# Altium schematic sheet LTE_adapter_Rev02.SchDoc
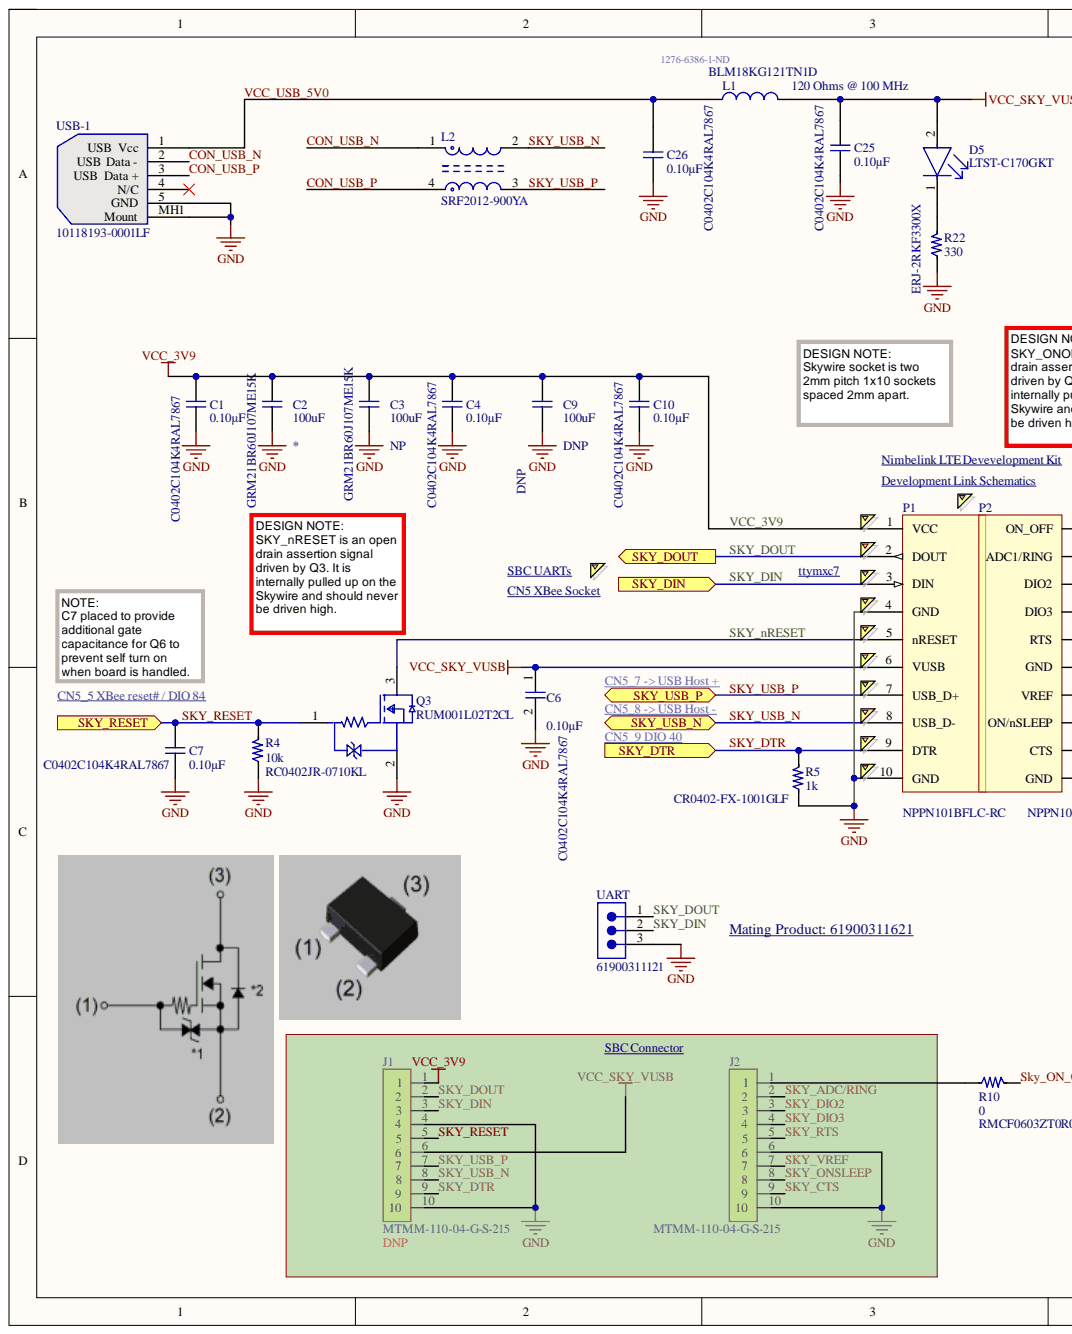
[diagram p0: LTE_adapter_Rev02.SchDoc, left]
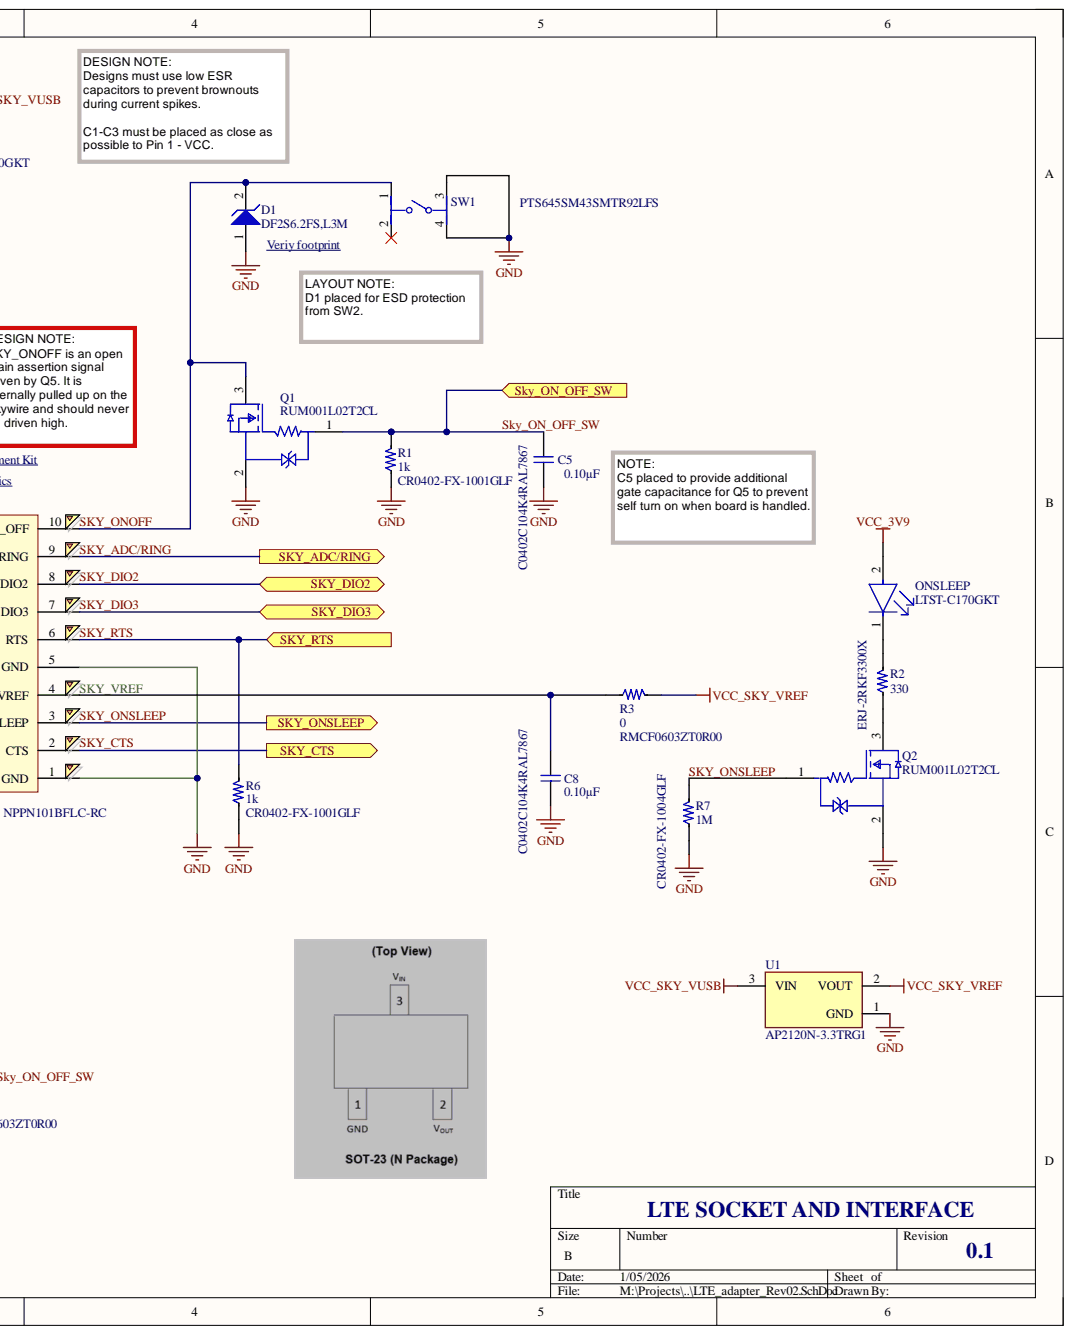
[diagram p1: LTE_adapter_Rev02.SchDoc, right]

## Parts
C1  C0402C104K4RAL7867  Capacitance: 0.10µF  [SMD CAP 1005]
C2  GRM21BR60J107ME15K  Capacitance: 100uF  [CAPC1210M]
C3  GRM21BR60J107ME15K  Capacitance: 100uF  Population: NP  [CAPC1210M]
C4  C0402C104K4RAL7867  Capacitance: 0.10µF  [SMD CAP 1005]
C5  C0402C104K4RAL7867  Capacitance: 0.10µF  [SMD CAP 1005]
C6  C0402C104K4RAL7867  Capacitance: 0.10µF  [SMD CAP 1005]
C7  C0402C104K4RAL7867  Capacitance: 0.10µF  [SMD CAP 1005]
C8  C0402C104K4RAL7867  Capacitance: 0.10µF  [SMD CAP 1005]
C9  DNP  Capacitance: 100uF  [CAPC1210M]
C10  C0402C104K4RAL7867  Capacitance: 0.10µF  [SMD CAP 1005]
C25  C0402C104K4RAL7867  Capacitance: 0.10µF  [SMD CAP 1005]
C26  C0402C104K4RAL7867  Capacitance: 0.10µF  [SMD CAP 1005]
D1  DF2S6.2FS,L3M  [SODFL1006X40N]
D5  LTST-C170GKT  [FP-LTST-C170GKT-MFG]
J1  MTMM-110-04-G-S-215  Assy Note: DNP  [HDRV10W50P200_10X1_2000X200H696]
J2  MTMM-110-04-G-S-215  [HDRV10W50P200_10X1_2000X200H696]
L1  BLM18KG121TN1D  value 120 Ohms @ 100 MHz  Supplier Part Number 1: 1276-6386-1-ND  [INDC1608M]
L2  SRF2012-900YA  [FP-SRF2012A-MFG]
ONSLEEP  LTST-C170GKT  [FP-LTST-C170GKT-MFG]
P1  NPPN101BFLC-RC  [NPPN101BFLC-RC]
P2  NPPN101BFLC-RC  [NPPN101BFLC-RC]
Q1  RUM001L02T2CL  [FP-VMT3-MFG]
Q2  RUM001L02T2CL  [FP-VMT3-MFG]
Q3  RUM001L02T2CL  [FP-VMT3-MFG]
R1  CR0402-FX-1001GLF  Resistance_Ohms: 1k  [SMD RES 1005]
R2  ERJ-2RKF3300X  [SMD RES 1005]
R3  RMCF0603ZT0R00  [RESC1608M]
R4  RC0402JR-0710KL  Resistance_Ohms: 10k  [SMD RES 1005]
R5  CR0402-FX-1001GLF  Resistance_Ohms: 1k  [SMD RES 1005]
R6  CR0402-FX-1001GLF  Resistance_Ohms: 1k  [SMD RES 1005]
R7  CR0402-FX-1004GLF  Resistance: 1M  [SMD RES 1005]
R10  RMCF0603ZT0R00  [RESC1608M]
R22  ERJ-2RKF3300X  [SMD RES 1005]
SW1  PTS645SM43SMTR92LFS  [FP-PTS645SM43SMTR92LFS-MFG]
U1  AP2120N-3.3TRG1  [FP-SOT23-MFG]
UART  61900311121  [61900311121]
USB-1  10118193-0001LF  [10118193-0001LF Rev01]

## Ports
SKY_ADC/RING, SKY_CTS, SKY_DIN, SKY_DIO2, SKY_DIO3, SKY_DOUT, SKY_DTR, Sky_ON_OFF_SW, SKY_ONSLEEP, SKY_RESET, SKY_RTS, SKY_USB_N, SKY_USB_P

## Nets
GND: C1.2 C2.2 C3.2 C4.2 C5.2 C6.2 C7.2 C8.2 C9.2 C10.2 C25.2 C26.2 D1.1 J1.4 J1.10 J2.6 J2.10 P1.4(GND) P1.10(GND) P2.1(GND) P2.5(GND) Q1.2 Q2.2 Q3.2 R1.1 R4.1 R5.1 R6.1 R7.1 R22.1 SW1.3 SW1.4 U1.1 UART.3 USB-1.5(GND) USB-1.MH1(Mount)
VCC_SKY_VREF: R3.2 U1.2
VCC_SKY_VUSB: C6.1 C25.1 D5.2 J1.6 L1.1 P1.6(VUSB) U1.3
CON_USB_N: L2.1 USB-1.2(Data_-)
CON_USB_P: L2.4 USB-1.3(Data_+)
SKY_ADC/RING: J2.2 P2.9(ADC1/RING) ; port SKY_ADC/RING
SKY_CTS: J2.9 P2.2(CTS) ; port SKY_CTS
SKY_DIN: J1.3 P1.3(DIN) UART.2 ; port SKY_DIN
SKY_DIO2: J2.3 P2.8(DIO2) ; port SKY_DIO2
SKY_DIO3: J2.4 P2.7(DIO3) ; port SKY_DIO3
SKY_DOUT: J1.2 P1.2(DOUT) UART.1 ; port SKY_DOUT
SKY_DTR: J1.9 P1.9(DTR) R5.2 ; port SKY_DTR
SKY_nRESET: P1.5(nRESET) Q3.3
Sky_ON_OFF_SW: C5.1 Q1.1 R1.2 R10.2 ; port Sky_ON_OFF_SW
SKY_ONOFF: D1.2 P2.10(ON_OFF) Q1.3 SW1.1
SKY_ONSLEEP: J2.8 P2.3(ON/nSLEEP) Q2.1 R7.2 ; port SKY_ONSLEEP
SKY_RESET: C7.1 J1.5 Q3.1 R4.2 ; port SKY_RESET
SKY_RTS: J2.5 P2.6(RTS) R6.2 ; port SKY_RTS
SKY_USB_N: J1.8 L2.2 P1.8(USB_D-) ; port SKY_USB_N
SKY_USB_P: J1.7 L2.3 P1.7(USB_D+) ; port SKY_USB_P
SKY_VREF: C8.1 J2.7 P2.4(VREF) R3.1
VCC_3V9: C1.1 C2.1 C3.1 C4.1 C9.1 C10.1 J1.1 ONSLEEP.2 P1.1(VCC)
VCC_USB_5V0: C26.1 L1.2 USB-1.1(Vcc)
NetD5_1: D5.1 R22.2
NetJ2_1: J2.1 R10.1
NetONSLEEP_1: ONSLEEP.1 R2.2
NetQ2_3: Q2.3 R2.1
NetSW1_2: SW1.2
NetUSB-1_4: USB-1.4(N/C)

## Library data of the parts
C1 C4-C8 C10 C25 C26 = 1000742: 0.1 µF ±10% 16V Ceramic Capacitor X7R 0402 (1005 Metric); Manufacturer: KEMET; Manufacturer_Part_Number1: C0402C104K4RALTU; Item_Phase: Production; Mounting_Type: Surface Mount; Operating_Temperature: -55°C ~ 125°C; Thickness_Max: 0.022" (0.55mm); Value: 0.1uF; Applications: General Purpose; Bom_View: https://app.bom.com/a/YGZ57I1I1KJQDBOE?v=bom.indented; Capacitor_Type: Ceramic; Component Kind: Standard; Costing_View: https://app.bom.com/a/YGZ57I1I1KJQDBOE?v=costing.summary; Database Table Name: Capacitor; Datasheet_Link: http://www.samsungsem.com/kr/support/product-search/mlcc/CL05B104KO5NNNC.jsp; Digi-Key Part Number: 399-C0402C104K4RAL7867CT-ND; Distributor1: Digi-Key; Distributor2: Digi-Key; Distributor_Part_Number1: 399-6342-1-ND; Distributor_Part_Number2: 1276-1001-1-ND; Files_View: https://app.bom.com/a/YGZ57I1I1KJQDBOE?v=files.associations; Library Name: AltiumProductionDatabase.DbLib; Manufacturer1: Kemet; Manufacturer2: Samsung Electro-Mechanics America, Inc; Manufacturer Product Number: C0402C104K4RAL7867; Manufacturer_Part_Number2: CL05B104KO5NNNC; Package_Case: 0402 (1005 Metric); Part_Number: 1000742; Part_Type: Capacitor; Revision: A; Size_Dimension: 0.039" L x 0.020" W (1.00mm x 0.50mm); Sourcing_View: https://app.bom.com/a/YGZ57I1I1KJQDBOE?v=sourcing.relationships; Specs_View: https://app.bom.com/a/YGZ57I1I1KJQDBOE?v=spec.summary; Temperature_Coefficient: X7R; Tolerance: ±10%; Voltage_Rating: 16V
C2 C3 = 1001241: 100 µF ±20% 6.3V Ceramic Capacitor X5R 0805 (2012 Metric); Manufacturer: Murata Electronics; Manufacturer1: Taiyo Yuden; Manufacturer_Part_Number1: LMK325BJ107MM-T; Item_Phase: Production; Mounting_Type: Surface Mount; Operating_Temperature: -55C ~ +85C; Thickness_Max: 0.106 (2.70mm)"; Tolerance: +/- 20%; Applications: General Purpose; Bom_View: https://app.bom.com/a/FXGMOZIZI107USQH?v=bom.indented; Capacitor_Type: Ceramic; Component Kind: Standard; Costing_View: https://app.bom.com/a/FXGMOZIZI107USQH?v=costing.summary; Database Table Name: Capacitor; Datasheet_Link: http://www.yuden.co.jp/productdata/catalog/en/mlcc_all_e.pdf; Digi-Key Part Number: 490-GRM21BR60J107ME15KCT-ND; Distributor1: Digi-Key; Distributor_Part_Number1: 587-1965-1-ND; Files_View: https://app.bom.com/a/FXGMOZIZI107USQH?v=files.associations; Library Name: AltiumProductionDatabase.DbLib; Manufacturer Product Number: GRM21BR60J107ME15K; Package_Case: 1210 (3225 Metric); Part_Number: 1001241; Part_Type: Capacitor; Revision: A; Size_Dimension: 0.126 L x 0.098" W (3.20mm x 2.50mm); Sourcing_View: https://app.bom.com/a/FXGMOZIZI107USQH?v=sourcing.relationships; Specs_View: https://app.bom.com/a/FXGMOZIZI107USQH?v=spec.summary; Temperature_Coefficient: X5R; Voltage_Rating: 6.3V
C9 = 1001241: 100 µF ±20% 6.3V Ceramic Capacitor X5R 0805 (2012 Metric); Manufacturer1: Taiyo Yuden; Manufacturer_Part_Number1: LMK325BJ107MM-T; Item_Phase: Production; Mounting_Type: Surface Mount; Operating_Temperature: -55C ~ +85C; Population: DNP; Thickness_Max: 0.106 (2.70mm)"; Tolerance: +/- 20%; Voltage_Rating: 10V; Applications: General Purpose; Bom_View: https://app.bom.com/a/FXGMOZIZI107USQH?v=bom.indented; Capacitor_Type: Ceramic; Component Kind: Standard; Costing_View: https://app.bom.com/a/FXGMOZIZI107USQH?v=costing.summary; Database Table Name: Capacitor; Datasheet_Link: http://www.yuden.co.jp/productdata/catalog/en/mlcc_all_e.pdf; Digi-Key Part Number: DNP; Distributor1: Digi-Key; Distributor_Part_Number1: 587-1965-1-ND; Files_View: https://app.bom.com/a/FXGMOZIZI107USQH?v=files.associations; Library Name: AltiumProductionDatabase.DbLib; Manufacturer: DNP; Manufacturer Product Number: DNP; Package_Case: 1210 (3225 Metric); Part_Number: 1001241; Part_Type: Capacitor; Revision: A; Size_Dimension: 0.126 L x 0.098" W (3.20mm x 2.50mm); Sourcing_View: https://app.bom.com/a/FXGMOZIZI107USQH?v=sourcing.relationships; Specs_View: https://app.bom.com/a/FXGMOZIZI107USQH?v=spec.summary; Temperature_Coefficient: X5R
D1 = D Zener: Clamp Ipp Tvs Diode Surface Mount SOD-923; Manufacturer: Toshiba Semiconductor and Storage; Component Kind: Standard; Digi-Key Part Number: DF2S6.2FSL3MCT-ND; LatestRevisionDate: 17-Jul-2002; LatestRevisionNote: Re-released for DXP Platform.; Library Name: Miscellaneous Devices.IntLib; Manufacturer Product Number: DF2S6.2FS,L3M; PackageDescription: Diode, Thru-Hole; 2 Leads; 0.7 in Pin Spacing; PackageReference: SOD-923; Published: 8-Jun-2000; Signal Integrity: D Zener; Simulation: ZENER; Value: TVS; Publisher: Altium Limited
D5 ONSLEEP = CMP-1674-00002-6: Green 569nm LED Indication - Discrete 2.1V 0805 (2012 Metric); Manufacturer: Lite-On Inc.; Forward Current: 30mA; Lens Color: Colorless; Lens Style: Diffused; Lens Transparency: Clear; Luminous Intensity: 6mcd; Number of Elements: 1; Power Dissipation: 100mW; Reverse Voltage: 5V; Test Current: 10mA; Viewing Angle: 130°; Wavelength: 565nm; Case Code (Imperial): 0805; Case Code (Metric): 2012; Case/Package: 0805; Color: Green; Component Kind: Standard; Depth: 1.25mm; Digi-Key Part Number: 160-1179-1-ND; Dominant Wavelength: 569nm; Forward Voltage: 2.1V; Height: 1.1mm; Illumination Color: Green; Lead Free: Lead Free; Length: 2mm; Library Name: Altium Content Vault; Manufacturer Product Number: LTST-C170GKT; Max Operating Temperature: 85°C; Min Operating Temperature: -55°C; Mount: Surface Mount; Number of LEDs: 1; Number of Pins: 2; Package Quantity: 1; Packaging: Tape and Reel; Power Rating: 100mW; Radiation Hardening: No; Value: LED; Voltage: 5V; Width: 1.25mm
J1 = MTMM-110-04-G-S-215: Connector Header Through Hole 10 position 0.079" (2.00mm); Manufacturer: Samtec Inc.; Value: Connector Board to Board LTE; Availability: Unavailable; Component Kind: Standard; Digi-Key Part Number: 612-MTMM-110-04-G-S-215-ND; Library Name: MTMM-110-04-G-S-215.IntLib; Manufacturer Product Number: MTMM-110-04-G-S-215; MP: MTMM-110-04-G-S-215; Package: None; Price: None
J2 = MTMM-110-04-G-S-215: Connector Header Through Hole 10 position 0.079" (2.00mm); Manufacturer: Samtec Inc.; Assy Note: DNP; Value: Connector Board to Board LTE; Availability: Unavailable; Component Kind: Standard; Digi-Key Part Number: 612-MTMM-110-04-G-S-215-ND; Library Name: MTMM-110-04-G-S-215.IntLib; Manufacturer Product Number: MTMM-110-04-G-S-215; MP: MTMM-110-04-G-S-215; Package: None; Price: None
L1 = Inductor: 120 Ohms @ 100 MHz 1 Power Line Ferrite Bead 0603 (1608 Metric) 3A 30mOhm; Manufacturer: Murata Electronics; Code_IEC: 1005; Code_JEITA: 0402; Component Kind: Standard; Cost: 0.02594; Digi-Key Part Number: 490-5254-1-ND; LatestRevisionDate: 29-May-2009; LatestRevisionNote: IPC-7351 Footprint Added.; Library Name: Miscellaneous Devices.IntLib; Manufacturer Product Number: BLM18KG121TN1D; PackageDescription: Chip Inductor; PackageReference: 0402-A; Published: 8-Jun-2000; Signal Integrity: Inductor; Simulation: INDUCTOR; Supplier 1: Digi-Key; Value: 120 Ohms @ 100 MHz; Publisher: Altium Limited
L2 = CMP-07251-000007-1: 2 Line Common Mode Choke Surface Mount 90 Ohms @ 100 MHz 400mA DCR 300mOhm; Manufacturer: Bourns Inc.; Core Material: Ferrite; DC Resistance (DCR): 300mR; Element Configuration: Dual; Impedance: 90R; Insulation Resistance: 10MR; Material: Steel; Number of Lines: 2; Shielding: Unshielded; Test Frequency: 100MHz; Tolerance: 25%; Voltage Rating (DC): 50V; Case/Package: 0805; Component Kind: Standard; ComponentLink1Description: Datasheet; Current: 400mA; Current Rating: 400mA; DC Current: 400mA; Depth: 1.4mm; Digi-Key Part Number: SRF2012-900YACT-ND; Halogen Free: Halogen Free; Height: 1.2mm; Length: 2mm; Library Name: Altium Content Vault; Manufacturer Product Number: SRF2012-900YA; Max DC Current: 400mA; Max Operating Temperature: 125°C; Min Operating Temperature: -40°C; Mount: Surface Mount; Package Quantity: 1; Packaging: Tape and Reel; REACH SVHC: No SVHC; Resistance: 90R; RoHS Compliant: Yes; Series: SRF2012A; Termination: SMD/SMT; Width: 1.2mm; ComponentLink1URL: C:\Users\Roman.Chak\Documents\Projects\UVDI-360\Docs\Datasheets\Inductor\SRF2012A.pdf
P1 = Skywire_Header_1_10: CONN HDR 10POS 0.079 GOLD SMD; Manufacturer: Sullins Connector Solutions; Value: Connector LTE; Component Kind: Standard; ComponentLink1Description: Digi-Key; ComponentLink1URL: https://www.digikey.com/en/products/detail/sullins-connector-solutions/NPPN101BFLC-RC/810012?s=N4IgTCBcDaIMoFYCcAGAjAWjSjA5AIiALoC%2BQA; ComponentLink2Description: Datasheet; Digi-Key Part Number: S5901-10-ND; Library Name: Adapter_Board.SchLib; Manufacturer Product Number: NPPN101BFLC-RC; ComponentLink2URL: C:\Users\Roman.Chak\Documents\Projects\UVDI-360\Docs\Datasheets\Header\10489_NPPN101BFLC-RC.pdf
P2 = skywire_header_11_20: CONN HDR 10POS 0.079 GOLD SMD; Manufacturer: Sullins Connector Solutions; Value: Connector LTE; Component Kind: Standard; ComponentLink1Description: Datasheet; Digi-Key Part Number: S5901-10-ND; Library Name: Adapter_Board.SchLib; Manufacturer Product Number: NPPN101BFLC-RC; ComponentLink1URL: C:\Users\Roman.Chak\Documents\Projects\UVDI-360\Docs\Datasheets\Header\10489_NPPN101BFLC-RC.pdf
Q1-Q3 = RUM001L02T2CL: N-Channel 20 V 100mA (Ta) 150mW (Ta) Surface Mount VMT3; Manufacturer: Rohm Semiconductor; Component Kind: Standard; ComponentLink1Description: Datasheet; Digi-Key Part Number: RUM001L02T2CLCT-ND; Library Name: MOSFET.SchLib; Manufacturer Product Number: RUM001L02T2CL; ComponentLink1URL: C:\Users\Roman.Chak\Documents\Projects\UVDI-360\Docs\Datasheets\MOSFET\rum001l02t2cl-e.pdf
R1 R5 R6 = 1000794: 1 kOhms ±1% 0.063W, 1/16W Chip Resistor 0402 (1005 Metric) Thick Film; Manufacturer1: Bourns Inc; Item_Phase: Production; Mounting_Type: Surface Mount; Number_of_Terminations: 2; Operating_Temperature: -55°C ~ 155°C; Temperature_Coefficient: ±100ppm/°C; Bom_View: https://app.bom.com/a/O6PVX8R8RA9G31AY?v=bom.indented; Component Kind: Standard; Composition: Thick Film; Costing_View: https://app.bom.com/a/O6PVX8R8RA9G31AY?v=costing.summary; Database Table Name: Resistor; Datasheet_Link: http://media.digikey.com/pdf/Data%20Sheets/Samsung%20PDFs/RC_Series_ds.pdf; Digi-Key Part Number: CR0402-FX-1001GLFCT-ND; Distributor1: Digi-Key; Distributor2: Digi-Key; Distributor_Part_Number1: CR0402-FX-1001GLFTR-ND; Distributor_Part_Number2: 1276-3430-2-ND; Features: Moisture Resistant; Files_View: https://app.bom.com/a/O6PVX8R8RA9G31AY?v=files.associations; Height: 0.016" (0.40mm); Library Name: AltiumProductionDatabase.DbLib; Manufacturer: CR0402-FX-1001GLF; Manufacturer2: Samsung Electro-Mechanics America, Inc; Manufacturer Product Number: CR0402-FX-1001GLF; Manufacturer_Part_Number1: CR0402-FX-1001GLF; Manufacturer_Part_Number2: RC1005F102CS; Part_Number: CR0402-FX-1001GLF; Part_Type: Resistor; Power: 0.063W, 1/16W; Resistor_Package: 0402 (1005 Metric); Revision: A; Size_Dimension: 0.039" L x 0.020" W (1.00mm x 0.50mm); Sourcing_View: https://app.bom.com/a/O6PVX8R8RA9G31AY?v=sourcing.relationships; Specs_View: https://app.bom.com/a/O6PVX8R8RA9G31AY?v=spec.summary; Tolerance: ±1%
R2 R22 = 1001162: 330 Ohms ±1% 0.1W, 1/10W Chip Resistor 0402 (1005 Metric) Automotive AEC-Q200 Thick Film; Manufacturer: Panasonic Electronic Components; Item_Phase: Production; Mounting_Type: Surface Mount; Number_of_Terminations: 2; Operating_Temperature: -55°C ~ 155°C; Resistance: 330; Temperature_Coefficient: ±100ppm/°C; Bom_View: https://app.bom.com/a/1J28AL4L4NMTGF0H?v=bom.indented; Component Kind: Standard; Composition: Thick Film; Costing_View: https://app.bom.com/a/1J28AL4L4NMTGF0H?v=costing.summary; Database Table Name: Resistor; Datasheet_Link: http://industrial.panasonic.com/www-cgi/jvcr13pz.cgi?E+PZ+3+AOA0002+ERJ2RKF3300X+7+WW; Digi-Key Part Number: P330LCT-ND; Distributor1: Digi-Key; Distributor_Part_Number1: P330LCT-ND; Features: Automotive AEC-Q200; Files_View: https://app.bom.com/a/1J28AL4L4NMTGF0H?v=files.associations; Height: 0.016" (0.40mm); Library Name: AltiumProductionDatabase.DbLib; Manufacturer1: Panasonic Electronic Components; Manufacturer Product Number: ERJ-2RKF3300X; Manufacturer_Part_Number1: ERJ-2RKF3300X; Part_Number: 1001162; Part_Type: Resistor; Power: 0.1W, 1/10W; Resistor_Package: 0402 (1005 Metric); Revision: A; Size_Dimension: 0.039" L x 0.020" W (1.00mm x 0.50mm); Sourcing_View: https://app.bom.com/a/1J28AL4L4NMTGF0H?v=sourcing.relationships; Specs_View: https://app.bom.com/a/1J28AL4L4NMTGF0H?v=spec.summary; Tolerance: ±1%
R3 R10 = 1001176: 0 Ohms Jumper Chip Resistor 0603 (1608 Metric) Automotive AEC-Q200 Thick Film; Manufacturer: Stackpole Electronics Inc; Item_Phase: Production; Mounting_Type: Surface Mount; Number_of_Terminations: 2; Operating_Temperature: -55°C ~ 125°C; Power: 0.25W, 1/4W; Resistance: 0; Resistor_Package: 0805 (2012 Metric); Temperature_Coefficient: ±100ppm/°C; Tolerance: ±1%; Bom_View: https://app.bom.com/a/Q8RXZATATCBI53HK?v=bom.indented; Component Kind: Standard; Composition: Thick Film; Costing_View: https://app.bom.com/a/Q8RXZATATCBI53HK?v=costing.summary; Database Table Name: Resistor; Datasheet_Link: http://industrial.panasonic.com/lecs/www-data/pdf/AOA0000/AOA0000CE3.pdf; Digi-Key Part Number: RMCF0603ZT0R00CT-ND; Distributor1: Digi-Key; Distributor_Part_Number1: RMCF0603ZT0R00CT-ND; Features: Automotive AEC-Q200, Current Sense; Files_View: https://app.bom.com/a/Q8RXZATATCBI53HK?v=files.associations; Height: 0.028" (0.70mm); Library Name: AltiumProductionDatabase.DbLib; Manufacturer1: Stackpole Electronics Inc; Manufacturer Product Number: RMCF0603ZT0R00; Part_Number: RMCF0603ZT0R00; Part_Type: Resistor; Revision: A; Size_Dimension: 0.079" L x 0.049" W (2.00mm x 1.25mm); Sourcing_View: https://app.bom.com/a/Q8RXZATATCBI53HK?v=sourcing.relationships; Specs_View: https://app.bom.com/a/Q8RXZATATCBI53HK?v=spec.summary
R4 = 1000795: 10 kOhms ±5% 0.063W, 1/16W Chip Resistor 0402 (1005 Metric) Moisture Resistant Thick Film; Manufacturer: YAGEO; Manufacturer1: Bourns Inc; Manufacturer_Part_Number1: CR0402-FX-1002GLF; Item_Phase: Production; Mounting_Type: Surface Mount; Number_of_Terminations: 2; Operating_Temperature: -55°C ~ 155°C; Temperature_Coefficient: ±100ppm/°C; Tolerance: ±1%; Bom_View: https://app.bom.com/a/P7QWY9S9SBAH42BP?v=bom.indented; Component Kind: Standard; Composition: Thick Film; Costing_View: https://app.bom.com/a/P7QWY9S9SBAH42BP?v=costing.summary; Database Table Name: Resistor; Datasheet_Link: http://media.digikey.com/pdf/Data%20Sheets/Samsung%20PDFs/RC_Series_ds.pdf; Digi-Key Part Number: 311-10KJRCT-ND; Distributor1: Digi-Key; Distributor2: Digi-Key; Distributor_Part_Number1: CR0402-FX-1002GLFTR-ND; Distributor_Part_Number2: CR0402-FX-1002GASTR-ND; Features: Moisture Resistant; Files_View: https://app.bom.com/a/P7QWY9S9SBAH42BP?v=files.associations; Height: 0.016" (0.40mm); Library Name: AltiumProductionDatabase.DbLib; Manufacturer2: Bourns Inc; Manufacturer Product Number: RC0402JR-0710KL; Manufacturer_Part_Number2: CR0402-FX-1002GAS; Part_Number: RC0402JR-0710KL; Part_Type: Resistor; Power: 0.063W, 1/16W; Resistor_Package: 0402 (1005 Metric); Revision: A; Size_Dimension: 0.039" L x 0.020" W (1.00mm x 0.50mm); Sourcing_View: https://app.bom.com/a/P7QWY9S9SBAH42BP?v=sourcing.relationships; Specs_View: https://app.bom.com/a/P7QWY9S9SBAH42BP?v=spec.summary
R7 = 1000797: 1 MOhms ±1% 0.063W, 1/16W Chip Resistor 0402 (1005 Metric) Thick Film; Manufacturer: Bourns Inc.; Manufacturer1: Bourns Inc; Item_Phase: Production; Mounting_Type: Surface Mount; Number_of_Terminations: 2; Operating_Temperature: -55°C ~ 155°C; Temperature_Coefficient: ±100ppm/°C; Bom_View: https://app.bom.com/a/R9SY0BUBUDCJ64DV?v=bom.indented; Component Kind: Standard; Composition: Thick Film; Costing_View: https://app.bom.com/a/R9SY0BUBUDCJ64DV?v=costing.summary; Database Table Name: Resistor; Datasheet_Link: http://media.digikey.com/pdf/Data%20Sheets/Samsung%20PDFs/RC_Series_ds.pdf; Digi-Key Part Number: CR0402-FX-1004GLFCT-ND; Distributor1: Digi-Key; Distributor2: Digi-Key; Distributor_Part_Number1: CR0402-FX-1004GLFTR-ND; Distributor_Part_Number2: 1276-3433-2-ND; Features: Moisture Resistant; Files_View: https://app.bom.com/a/R9SY0BUBUDCJ64DV?v=files.associations; Height: 0.016" (0.40mm); Library Name: AltiumProductionDatabase.DbLib; Manufacturer2: Samsung Electro-Mechanics America, Inc; Manufacturer Product Number: CR0402-FX-1004GLF; Manufacturer_Part_Number1: CR0402-FX-1004GLF; Manufacturer_Part_Number2: RC1005F105CS; Part_Number: 1000797; Part_Type: Resistor; Power: 0.063W, 1/16W; Resistor_Package: 0402 (1005 Metric); Revision: A; Size_Dimension: 0.039" L x 0.020" W (1.00mm x 0.50mm); Sourcing_View: https://app.bom.com/a/R9SY0BUBUDCJ64DV?v=sourcing.relationships; Specs_View: https://app.bom.com/a/R9SY0BUBUDCJ64DV?v=spec.summary; Tolerance: ±1%
SW1 = CMP-2000-05160-2: SWITCH TACTILE SPST-NO 0.05A 12V; Manufacturer: C&K; Actuator Length: 0.85mm; Actuator Material: Nylon; Actuator Type: Standard; Contact Resistance: 100mR; Current Rating: 50mA; Illumination Type: Non-Illuminated; Mechanical Life: 100000Cycles; Operating Force: 160gf; Switch Function: Off-Mom; Throw Configuration: SPST; Case/Package: SMD/SMT; Color: Black; Component Kind: Standard; Contact Material: Silver; Contact Plating: Silver; Depth: 9mm; Digi-Key Part Number: CKN9112CT-ND; Lead Free: Lead Free; Length: 6mm; Library Name: Altium Content Vault; Manufacturer Product Number: PTS645SM43SMTR92 LFS; Max Current Rating: 50mA; Max Operating Temperature: 60°C; Max Voltage Rating (DC): 12V; Min Operating Temperature: -20°C; Mount: Surface Mount; Orientation: Straight; Package Quantity: 1; Packaging: Tape and Reel; REACH SVHC: No SVHC; Termination: Gull Wing; Value: Switch; Voltage Rating (DC): 12V
U1 = CMP-12756-000008-1: Linear Voltage Regulator IC Positive Fixed 1 Output 150mA SOT-23-3; Manufacturer: Diodes Incorporated; Accuracy Percentage: 2%; Dropout Voltage: 300mV; Max Junction Temp: +85°C; Max Supply Voltage: 6V; Min Supply Voltage: 2V; Nominal Supply Current: 25uA; Number Of Outputs: 1; Output Voltage: 3.3V; Standoff Height: 0.013mm; Automotive: No; Category: IC; Component Kind: Standard; ComponentLink2Description: Package; ComponentLink2URL: https://www.diodes.com/assets/Package-Files/SOT23.pdf; ComponentLink3Description: Datasheet; Device Class L1: Integrated Circuits (ICs); Device Class L2: Power Management ICs; Device Class L3: Voltage Regulators - Linear; Digi-Key Part Number: AP2120N-3.3TRG1DICT-ND; Digikey Description: IC REG LINEAR 3.3V 150MA SOT23; Footprint Url: https://www.diodes.com/assets/Package-Files/SOT23.pdf; Height: 1.1mm; Ipc Land Pattern Name: SOT92P240X102-3; Lead Free: Yes; Library Name: Altium Content Vault; Manufacturer Part Number: AP2120N-3.3TRG1; Manufacturer Product Number: AP2120N-3.3TRG1; Mouser Description: AP2120 Series 3.3 V 0.15 A Surface Mount CMOS LDO Regulator - SOT23; Octopart: https://octopart.com/ap2120n-3.3trg1-diodes+inc.-30954573; Output Current: 150mA; Output Type: Fixed; Package: SOT23; RoHS: TRUE; Temperature: -40°C to +85°C; Temperature Range High: +85°C; Temperature Range Low: -40°C; ComponentLink3URL: C:\Users\Roman.Chak\Documents\Projects\UVDI-360\Docs\Datasheets\Diodes Incorporated\AP2120.pdf
UART = CMP-1502-00585-2: Connector Header Through Hole 3 position 0.100" (2.54mm); Manufacturer: Würth Elektronik; NexarPartId: 18818222; Connector Type: Male Pin; Contact Resistance: 20mΩ; Current Rating: 3A; Gender: Male; Insulation Resistance: 1GΩ; Number of Contacts: 3; Operating Supply Voltage: 250V; Color: Beige; Component Kind: Standard; Contact Gender: Male; Contact Material: Copper; Contact Mating Length: 7.493mm; Contact Plating: Tin; DigiKey Part Number: 732-2696-ND; Fastening Type: Friction; Flammability Rating: UL94 V-0; Height: 12.5mm; Housing Material: Nylon; Lead Pitch: 2.54mm; Length: 7.62mm; Library Name: Altium Content Vault; Manufacturer Product Number: 61900311121; Max Operating Temperature: 105°C; Min Operating Temperature: -40°C; Mount: Through Hole; Number of Pins: 3; Number of Positions: 3; Number of Rows: 1; Orientation: Vertical; Packaging: Bulk; Pitch: 2.54mm; REACH SVHC: Unknown; RoHS: Compliant; Termination: Through Hole; Voltage Rating: 250V; Width: 7.66mm
USB-1 = USB mini: USB - micro B USB 2.0 Receptacle Connector 5 Position Surface Mount, Right Angle, Horizontal; Manufacturer: FCI; Alternative: None; Assembly Note: Install; Loc: Vendor; Protorype Price: 0.46000; Value: CONN USB MICRO B RECPT SMT R/A; Component Kind: Standard; ComponentLink1Description: C datasheet; ComponentLink2Description: Folder; Cost: 0.25988; Digi-Key Part Number: 609-4616-1-ND; Library Name: USB.SchLib; Manufacturer Part Number: 10118193-0001LF; Price for 100: 0.30320; Purchased by: Vendor; Supplier 1: Digi-Key; Supplier 2: Digi-Key; Type: SMD; ComponentLink1URL: C:\Users\rchak\Documents\Projects\WiFi Node Rev2.0\Docs\Datasheets\USB\10118193.pdf; ComponentLink2URL: C:\Users\rchak\Documents\Projects\WiFi Node Rev2.0\Docs\Datasheets\USB
